# Altium schematic sheet Antenna Supervisor.SchDoc
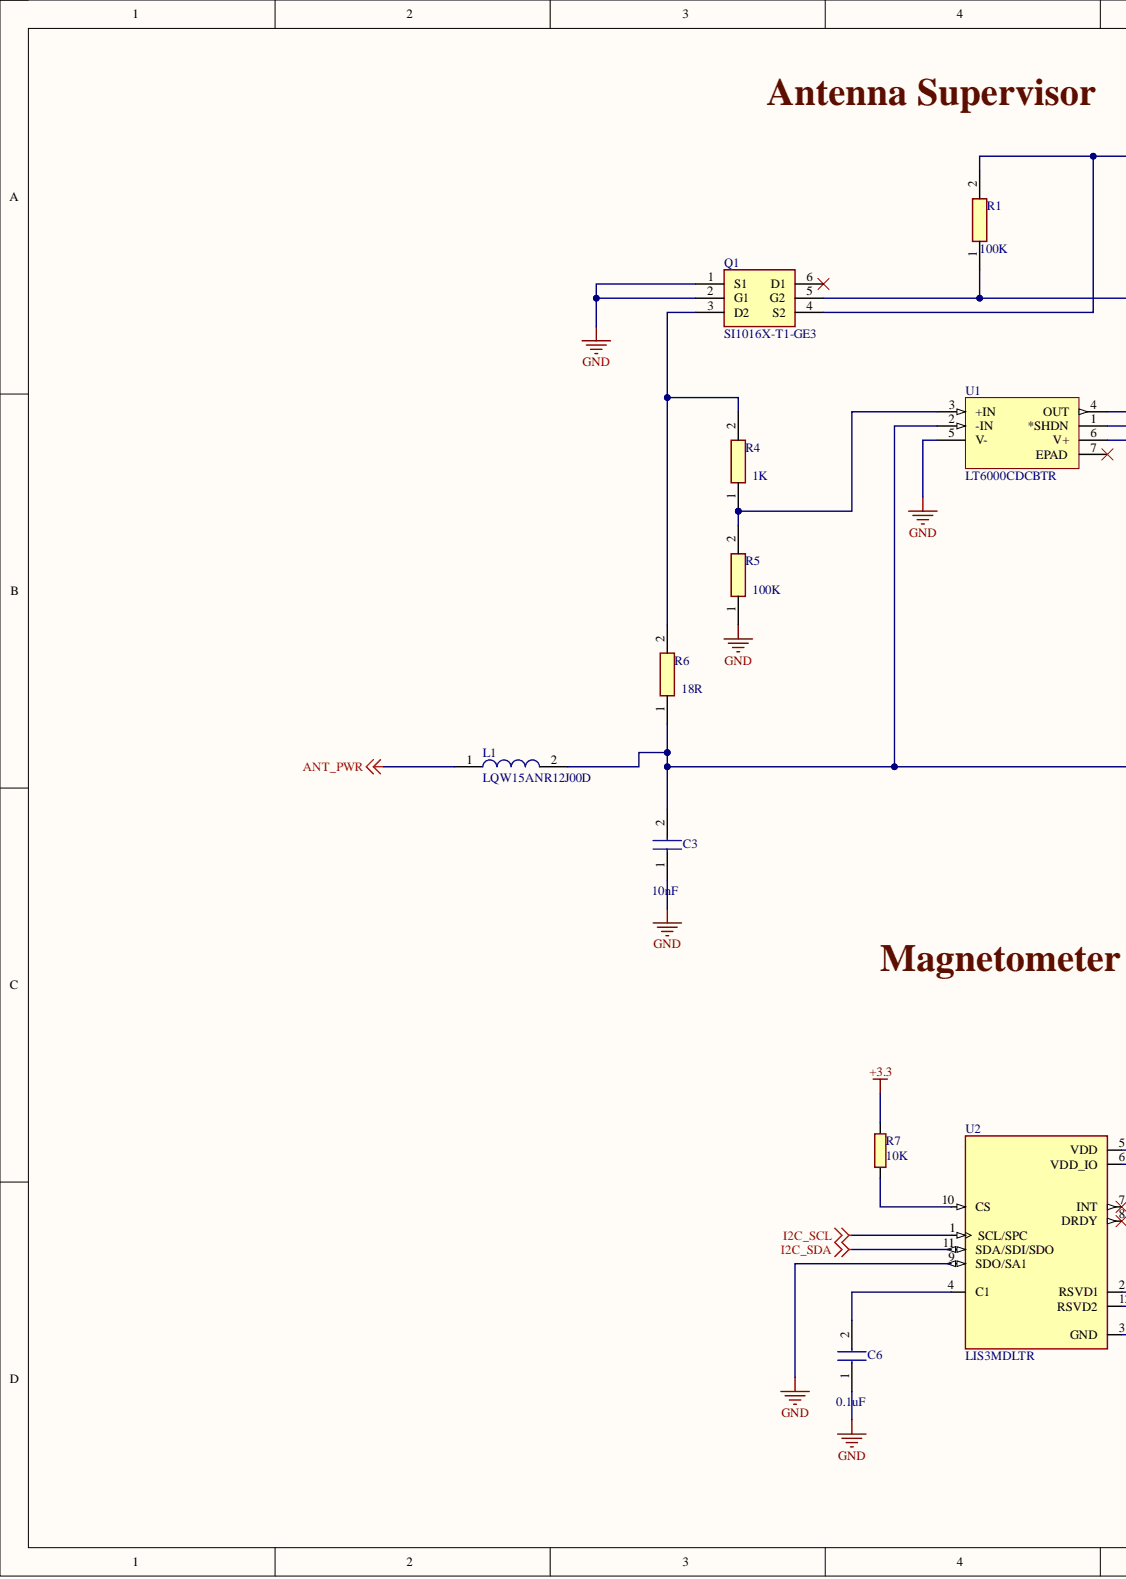
[diagram p0: Antenna Supervisor.SchDoc, left]
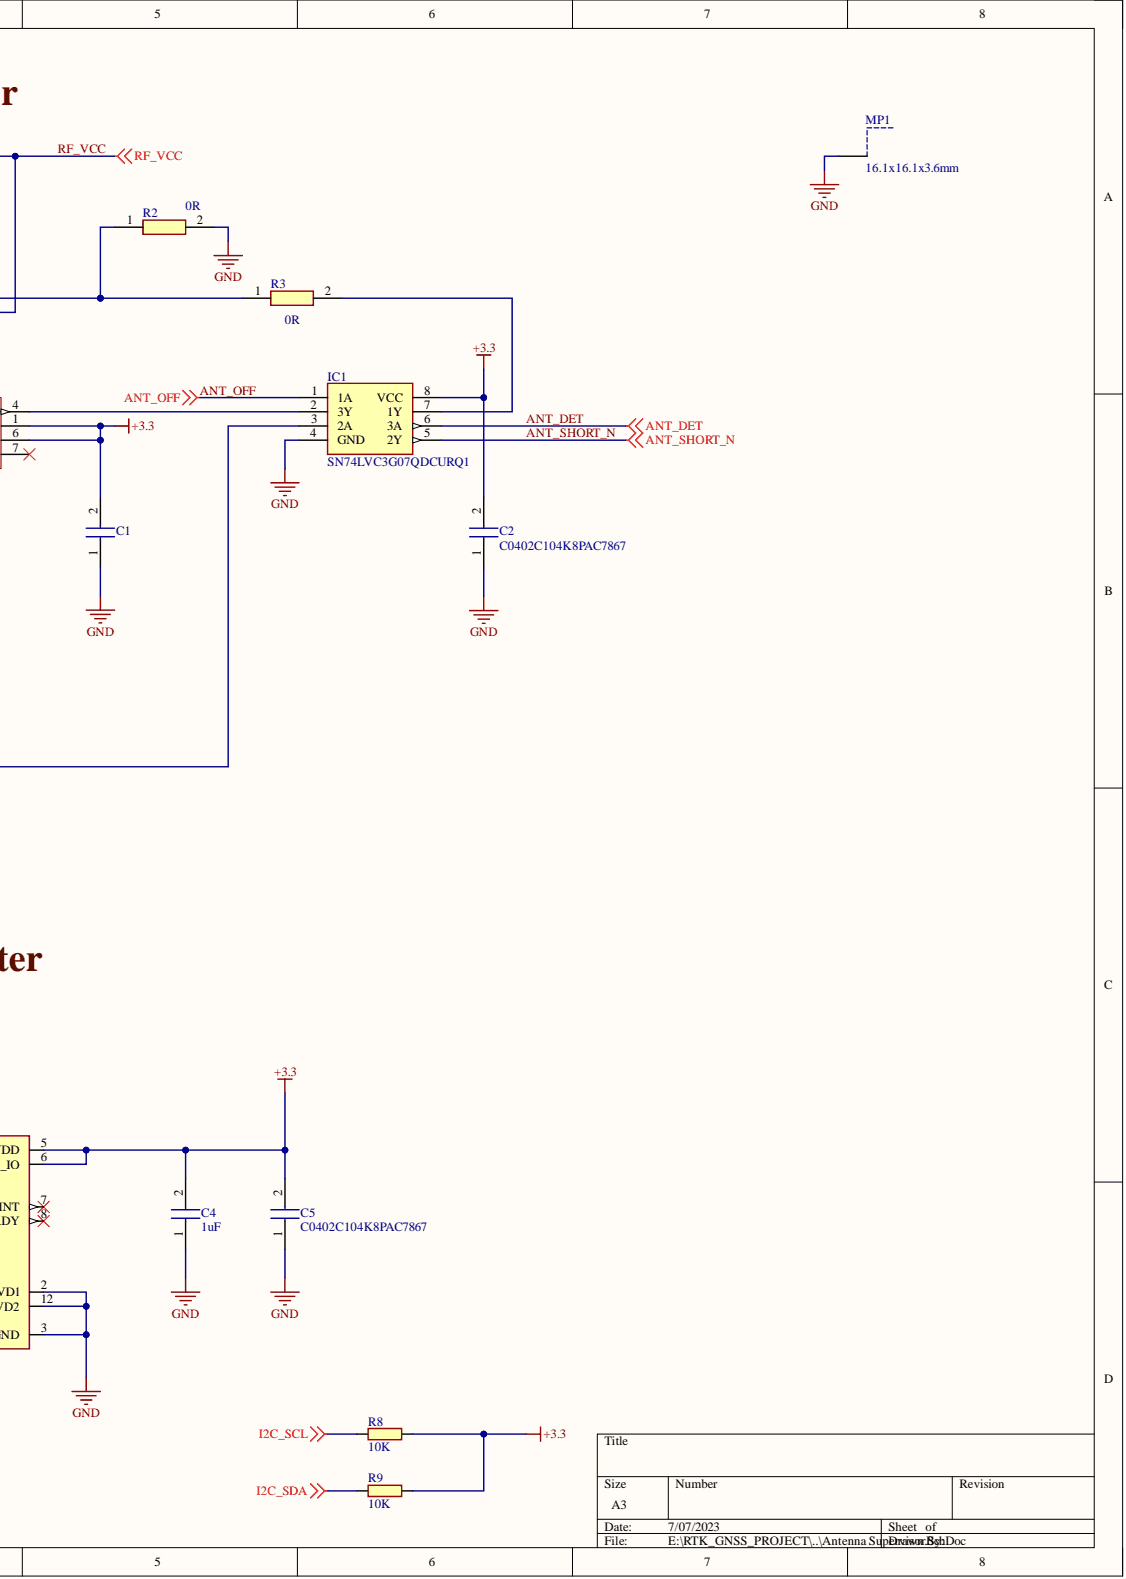
[diagram p1: Antenna Supervisor.SchDoc, right]

## Parts
C1  C0402C104K8PAC7867  [CAPC1005X60N]
C2  C0402C104K8PAC7867  [CAPC1005X60N]
C3  Parameter 1: 10nF  [CAPC0603X33N]  (hidden comment: GRM033R71C103KE14D)
C4  value 1uF  [CAPC3216X110N]  (hidden comment: C1206C105K5RACAUTO)
C5  C0402C104K8PAC7867  [CAPC1005X60N]
C6  C0402C104K8PAC7867  Parameter 1: 0.1uF  [CAPC1005X60N]
IC1  SN74LVC3G07QDCURQ1  [SOP50P310X90-8N]
L1  LQW15ANR12J00D  [LQW15CNR20J00B]
MP1  16.1x16.1x3.6mm  [36103166S]
Q1  SI1016X-T1-GE3  [SOTFL50P160X58-6N]
R1  Parameter 1: 100K  [RESC1005X40N]  (hidden comment: RC0402JR-07100KL)
R2  Parameter 1: 0R  [RESC1608X55N]  (hidden comment: RC0603JR-070RL)
R3  Parameter 1: 0R  [RESC1608X55N]  (hidden comment: RC0603JR-070RL)
R4  Parameter 1: 1K  [RESC1005X40N]  (hidden comment: RC0402JR-071KL)
R5  Parameter 1: 100K  [RESC1005X40N]  (hidden comment: RC0402JR-07100KL)
R6  Parameter 1: 18R  [RESC2012X70N]  (hidden comment: ERJ-P06J180V)
R7  value 10K  [0603 RESISTOR]  (hidden comment: RC0603FR-0710KL)
R8  value 10K  [0603 RESISTOR]  (hidden comment: RC0603FR-0710KL)
R9  value 10K  [0603 RESISTOR]  (hidden comment: RC0603FR-0710KL)
U1  LT6000CDCBTR  [DFN-6_DCB]
U2  LIS3MDLTR  [XDCR_LIS3MDLTR]

## Nets
+3.3: C1.2 C2.2 C4.2 C5.2 IC1.8(VCC) R7.1 R8.2 R9.2 U1.1(*SHDN) U1.6(V+) U2.5(VDD) U2.6(VDD_IO)
ANT_PWR: L1.1
GND: C1.1 C2.1 C3.1 C4.1 C5.1 C6.1 IC1.4(GND) MP1.1 Q1.1(S1) Q1.2(G1) R2.2 R5.1 U1.5(V-) U2.2(RSVD1) U2.3(GND) U2.9(SDO/SA1) U2.12(RSVD2)
I2C_SCL: R8.1 U2.1(SCL/SPC)
I2C_SDA: R9.1 U2.11(SDA/SDI/SDO)
ANT_DET: IC1.6(3A)
ANT_OFF: IC1.1(1A)
ANT_SHORT_N: IC1.5(2Y)
RF_VCC: Q1.4(S2) R1.2
NetC3_2: C3.2 IC1.3(2A) L1.2 R6.1 U1.2(-IN)
NetC6_2: C6.2 U2.4(C1)
NetIC1_2: IC1.2(3Y) U1.4(OUT)
NetIC1_7: IC1.7(1Y) R3.2
NetQ1_3: Q1.3(D2) R4.2 R6.2
NetQ1_5: Q1.5(G2) R1.1 R2.1 R3.1
NetR4_1: R4.1 R5.2 U1.3(+IN)
NetR7_2: R7.2 U2.10(CS)

Not connected: Q1 6(D1); U1 7(EPAD); U2 7(INT) 8(DRDY)

## Library data of the parts
C1 = C0402C104K8PACTU: 0.1µF ±10% 10V Ceramic Capacitor X5R 0402 (1005 Metric); Manufacturer_Name: Kemet; Parameter 1: 100nF; Arrow Part Number: C0402C104K8PACTU; Arrow Price/Stock: https://www.arrow.com/en/products/c0402c104k8pactu/kemet-corporation; Component Kind: Standard; Manufacturer_Part_Number: C0402C104K8PACTU; Mouser Part Number: 80-C0402C104K8P; Mouser Price/Stock: https://www.mouser.co.uk/ProductDetail/KEMET/C0402C104K8PACTU?qs=z2sx7fVyb8jnMSbeCX%252BaWg%3D%3D; Library Name: RTK_Schlib.SchLib
C2 C5 C6 = C0402C104K8PACTU: 0.1µF ±10% 10V Ceramic Capacitor X5R 0402 (1005 Metric); Manufacturer_Name: Kemet; Arrow Part Number: C0402C104K8PACTU; Arrow Price/Stock: https://www.arrow.com/en/products/c0402c104k8pactu/kemet-corporation; Component Kind: Standard; Manufacturer_Part_Number: C0402C104K8PACTU; Mouser Part Number: 80-C0402C104K8P; Mouser Price/Stock: https://www.mouser.co.uk/ProductDetail/KEMET/C0402C104K8PACTU?qs=z2sx7fVyb8jnMSbeCX%252BaWg%3D%3D; Library Name: RTK_Schlib.SchLib
C3 = GRM033R71C103KE14D: 10000pF ±10% 16V Ceramic Capacitor X7R 0201 (0603 Metric); Manufacturer_Name: Murata Electronics; Arrow Part Number: GRM033R71C103KE14D; Arrow Price/Stock: https://www.arrow.com/en/products/grm033r71c103ke14d/murata-manufacturing; Component Kind: Standard; Datasheet Link: https://psearch.en.murata.com/capacitor/product/GRM033R71C103KE14%23.html; Height: 0.33mm; Manufacturer_Part_Number: GRM033R71C103KE14D; Mouser Part Number: 81-GRM033R71C103KE4D; Mouser Price/Stock: https://www.mouser.co.uk/ProductDetail/Murata-Electronics/GRM033R71C103KE14D?qs=QzBtWTOodeUmwPFZnw4Ogg%3D%3D; Library Name: RTK_Schlib.SchLib
C4 = C1206C105K5RACAUTO: 1µF ±10% 50V Ceramic Capacitor X7R 1206 (3216 Metric); Manufacturer_Name: Kemet; Arrow Part Number: C1206C105K5RACAUTO; Arrow Price/Stock: https://www.arrow.com/en/products/c1206c105k5racauto/kemet-corporation; Component Kind: Standard; Datasheet Link: https://api.kemet.com/component-edge/download/datasheet/C1206C105K5RACAUTO.pdf; Height: 1.1mm; Manufacturer_Part_Number: C1206C105K5RACAUTO; Mouser Part Number: 80-C1206C105K5RAUTO; Mouser Price/Stock: https://www.mouser.co.uk/ProductDetail/KEMET/C1206C105K5RACAUTO/?qs=LWKvDm6ECZBUq0ahiO2pbw%3D%3D; Library Name: RTK_Schlib.SchLib
IC1 = SN74LVC3G07QDCURQ1: Buffer, Non-Inverting 3 Element 1 Bit per Element Open Drain Output 8-VSSOP; Manufacturer_Name: Texas Instruments; Component Kind: Standard; Datasheet Link: http://www.ti.com/lit/gpn/sn74lvc3g07-q1; Height: 0.9mm; Manufacturer_Part_Number: SN74LVC3G07QDCURQ1; Mouser Part Number: 595-SN74LVC3G07QDCQ1; Mouser Price/Stock: https://www.mouser.com/Search/Refine.aspx?Keyword=595-SN74LVC3G07QDCQ1; Library Name: RTK_Schlib.SchLib
L1 = LQW15ANR12J00D: 120nH Unshielded Wirewound Inductor 110mA 2.66Ohm Max 0402 (1005 Metric); Manufacturer_Name: Murata Electronics; Arrow Part Number: LQW15ANR12J00D; Arrow Price/Stock: https://www.arrow.com/en/products/lqw15anr12j00d/murata-manufacturing; Component Kind: Standard; Datasheet Link: https://psearch.en.murata.com/inductor/product/LQW15ANR12J00%23.html; Height: 0.6mm; Manufacturer_Part_Number: LQW15ANR12J00D; Mouser Part Number: 81-LQW15ANR12J00D; Mouser Price/Stock: https://www.mouser.co.uk/ProductDetail/Murata-Electronics/LQW15ANR12J00D/?qs=UV0HhPl4yOHsBH0%2FSRErMg%3D%3D; Library Name: RTK_Schlib.SchLib
MP1 = 36103166S: WE-SHC Shielding Cabinet, SMT Frame, Tin Plated, Tape and Reel, 16.1x16.1x3.6mm; Manufacturer: Wurth Elektronik; Base Material: Steel; Component Kind: Standard; ComponentLink1Description: Manufacturer; ComponentLink1URL: http://www.we-online.de; ComponentLink2Description: Datasheet; ComponentLink2URL: https://www.we-online.com/catalog/datasheet/36103166S.pdf; Height: 3.6mm; Length: 16.1mm; Manufacturer Part Number: 36103166S; Mounting Technology: SMT; Operating Temperature: -40 to +125degC; Packaging: Tape and Reel; Plating: Tin; Published Date: April 2020; Type: SMD Frame; Width: 16.1mm; Library Name: RTK_Schlib.SchLib
Q1 = SI1016X-T1-GE3: Mosfet Array N and P-Channel 20V 485mA, 370mA 250mW Surface Mount SC-89 (SOT-563F); Manufacturer_Name: Vishay; Allied_Number: 70459639; Allied Price/Stock: https://www.alliedelec.com/siliconix-vishay-si1016x-t1-ge3/70459639/; Component Kind: Standard; Datasheet Link: https://componentsearchengine.com/Datasheets/1/SI1016X-T1-GE3.pdf; Height: 0.6mm; Manufacturer_Part_Number: SI1016X-T1-GE3; Mouser Part Number: 781-SI1016X-T1-GE3; Mouser Price/Stock: https://www.mouser.com/Search/Refine.aspx?Keyword=781-SI1016X-T1-GE3; Library Name: RTK_Schlib.SchLib
R1 R5 = RC0402JR07100KL: 100 kOhms ±5% 0.063W, 1/16W Chip Resistor 0402 (1005 Metric) Moisture Resistant Thick Film; Manufacturer_Name: YAGEO (PHYCOMP); Arrow Part Number: RC0402JR07100KL; Arrow Price/Stock: https://www.arrow.com/en/products/rc0402jr-07100kl/yageo; Component Kind: Standard; Datasheet Link: http://www.yageo.com/documents/recent/PYu-RC0402_51_RoHS_L_6_r.pdf; Height: 0.4mm; Manufacturer_Part_Number: RC0402JR07100KL; Library Name: RTK_Schlib.SchLib
R2 R3 = RC0603JR-070RL: 0 Ohms Jumper 0.1W, 1/10W Chip Resistor 0603 (1608 Metric) Moisture Resistant Thick Film; Manufacturer_Name: YAGEO (PHYCOMP); Component Kind: Standard; Datasheet Link: http://www.yageo.com/documents/recent/PYu-RC0603_51_RoHS_L_v5.pdf; Height: 0.55mm; Manufacturer_Part_Number: RC0603JR-070RL; Mouser Part Number: 603-RC0603JR-070RL; Mouser Price/Stock: https://www.mouser.co.uk/ProductDetail/Yageo/RC0603JR-070RL?qs=2cAdsCoAWRGWyPcuXgGiXQ%3D%3D; Library Name: RTK_Schlib.SchLib
R4 = RC0402JR-071KL: 1 kOhms ±5% 0.063W, 1/16W Chip Resistor 0402 (1005 Metric) Moisture Resistant Thick Film; Manufacturer_Name: YAGEO (PHYCOMP); Arrow Part Number: RC0402JR-071KL; Arrow Price/Stock: https://www.arrow.com/en/products/rc0402jr-071kl/yageo; Component Kind: Standard; Datasheet Link: http://www.yageo.com/documents/recent/PYu-RC0402_51_RoHS_L_6_r.pdf; Height: 0.4mm; Manufacturer_Part_Number: RC0402JR-071KL; Mouser Part Number: 603-RC0402JR-071KL; Mouser Price/Stock: https://www.mouser.co.uk/ProductDetail/Yageo/RC0402JR-071KL/?qs=uM1JSSdPjOCtzNbByOhClA%3D%3D; Library Name: RTK_Schlib.SchLib
R6 = ERJ-P06J180V: 18 Ohms ±5% 0.5W, 1/2W Chip Resistor 0805 (2012 Metric) Automotive AEC-Q200, Pulse Withstanding Thick Film; Manufacturer_Name: Panasonic; Arrow Part Number: ERJ-P06J180V; Arrow Price/Stock: https://www.arrow.com/en/products/erj-p06j180v/panasonic; Component Kind: Standard; Datasheet Link: http://industrial.panasonic.com/cdbs/www-data/pdf/RDA0000/AOA0000C102.pdf; Height: 0.7mm; Manufacturer_Part_Number: ERJ-P06J180V; Mouser Part Number: 667-ERJ-P06J180V; Mouser Price/Stock: https://www.mouser.com/Search/Refine.aspx?Keyword=667-ERJ-P06J180V; Library Name: RTK_Schlib.SchLib
R7-R9 = 0603 SMD RESISTOR_1: 10 kOhms ±1% 0.1W, 1/10W Chip Resistor 0603 (1608 Metric) Moisture Resistant Thick Film; Component Kind: Standard; Library Name: Schlib.SchLib; Modified: Y
U1 = LT6000CDCBTR: General Purpose Amplifier 1 Circuit Rail-to-Rail 6-DFN _2x3_; MF: Linear Technology; MP: LT6000CDCB#TR; Availability: Unavailable; Component Kind: Standard; Package: DFN-6 Linear Technology; Price: None; SnapEDA_Link: https://www.snapeda.com/parts/LT6000CDCB%23TR/Analog%20Devices/view-part/605355/?ref=snap; Library Name: RTK_Schlib.SchLib
U2 = LIS3MDLTR: Magnetoresistive Sensor X, Y, Z Axis 12-LGA _2x2_; MF: STMicroelectronics; Availability: Unavailable; Component Kind: Standard; MP: LIS3MDLTR; Package: VFLGA-12 STMicroelectronics; Price: None; SnapEDA_Link: https://www.snapeda.com/parts/LIS3MDLTR/STMicroelectronics/view-part/382740/?ref=snap; Library Name: RTK_Schlib.SchLib
